# Altium schematic sheet USB3_Power.SchDoc
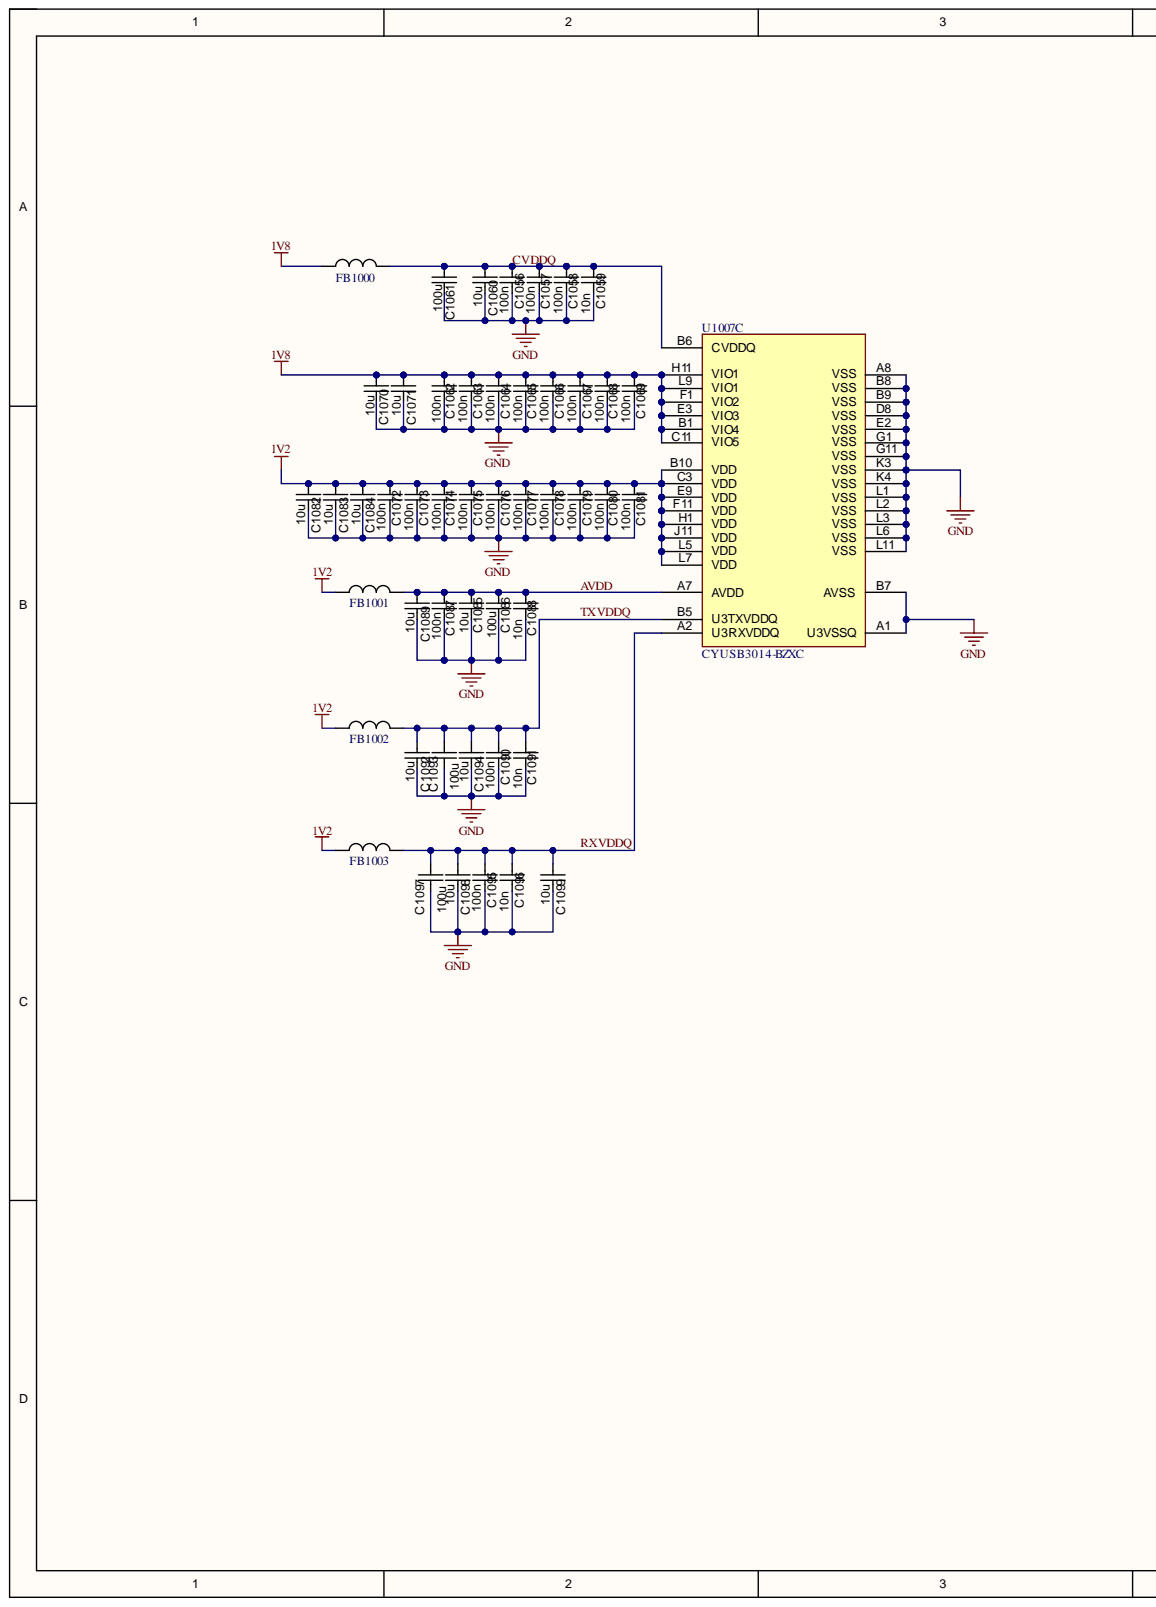
[diagram p0: USB3_Power.SchDoc, left]
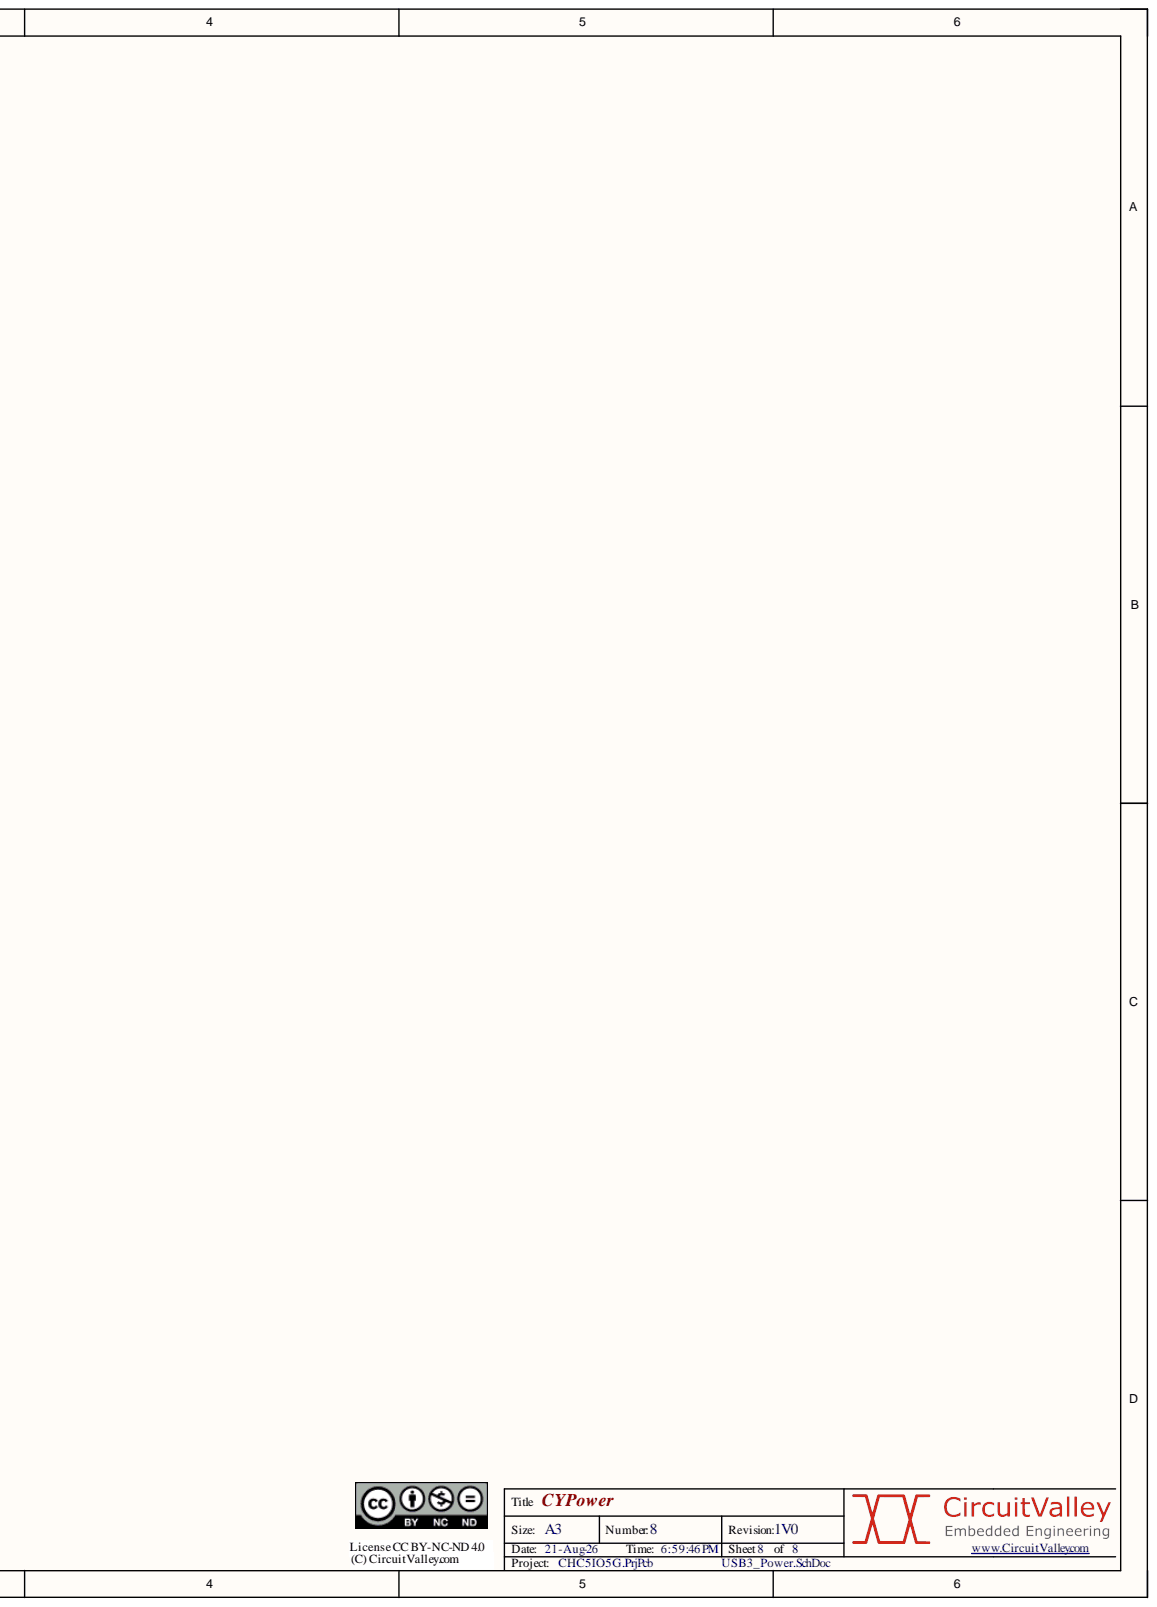
[diagram p1: USB3_Power.SchDoc, right]

## Parts
C1056  100n  [CAPC1005N]
C1057  100n  [CAPC1005N]
C1058  100n  [CAPC1005N]
C1059  10n  [CAPC1005N]
C1060  value 10u  [CAPC1608N]  (hidden comment: approved sub OK)
C1061  value 100u  [CAPC2012N]  (hidden comment: approved sub OK)
C1062  100n  [CAPC1005N]
C1063  100n  [CAPC1005N]
C1064  100n  [CAPC1005N]
C1065  100n  [CAPC1005N]
C1066  100n  [CAPC1005N]
C1067  100n  [CAPC1005N]
C1068  100n  [CAPC1005N]
C1069  100n  [CAPC1005N]
C1070  value 10u  [CAPC1608N]  (hidden comment: approved sub OK)
C1071  value 10u  [CAPC1608N]  (hidden comment: approved sub OK)
C1072  100n  [CAPC1005N]
C1073  100n  [CAPC1005N]
C1074  100n  [CAPC1005N]
C1075  100n  [CAPC1005N]
C1076  100n  [CAPC1005N]
C1077  100n  [CAPC1005N]
C1078  100n  [CAPC1005N]
C1079  100n  [CAPC1005N]
C1080  100n  [CAPC1005N]
C1081  100n  [CAPC1005N]
C1082  value 10u  [CAPC1608N]  (hidden comment: approved sub OK)
C1083  value 10u  [CAPC1608N]  (hidden comment: approved sub OK)
C1084  value 10u  [CAPC1608N]  (hidden comment: approved sub OK)
C1085  value 10u  [CAPC1608N]  (hidden comment: approved sub OK)
C1086  value 100u  [CAPC2012N]  (hidden comment: approved sub OK)
C1087  100n  [CAPC1005N]
C1088  10n  [CAPC1005N]
C1089  value 10u  [CAPC1608N]  (hidden comment: approved sub OK)
C1090  100n  [CAPC1005N]
C1091  10n  [CAPC1005N]
C1092  value 10u  [CAPC1608N]  (hidden comment: approved sub OK)
C1093  value 100u  [CAPC2012N]  (hidden comment: approved sub OK)
C1094  value 10u  [CAPC1608N]  (hidden comment: approved sub OK)
C1095  100n  [CAPC1005N]
C1096  10n  [CAPC1005N]
C1097  value 100u  [CAPC2012N]  (hidden comment: approved sub OK)
C1098  value 10u  [CAPC1608N]  (hidden comment: approved sub OK)
C1099  value 10u  [CAPC1608N]  (hidden comment: approved sub OK)
FB1000  Ferrite  [INDC1608N]  (value not drawn)
FB1001  Ferrite  [INDC1608N]  (value not drawn)
FB1002  Ferrite  [INDC1608N]  (value not drawn)
FB1003  Ferrite  [INDC1608N]  (value not drawn)
U1007  CYUSB3014-BZXC  [CYP-BZX121]  (part C)

## Nets
1V2: C1072.2 C1073.2 C1074.2 C1075.2 C1076.2 C1077.2 C1078.2 C1079.2 C1080.2 C1081.2 C1082.1 C1083.1 C1084.1 FB1001.1 FB1002.1 FB1003.1 U1007.B10(VDD) U1007.C3(VDD) U1007.E9(VDD) U1007.F11(VDD) U1007.H1(VDD) U1007.J11(VDD) U1007.L5(VDD) U1007.L7(VDD)
1V8: C1062.2 C1063.2 C1064.2 C1065.2 C1066.2 C1067.2 C1068.2 C1069.2 C1070.1 C1071.1 FB1000.1 U1007.B1(VIO4) U1007.C11(VIO5) U1007.E3(VIO3) U1007.F1(VIO2) U1007.H11(VIO1) U1007.L9(VIO1)
GND: C1056.1 C1057.1 C1058.1 C1059.1 C1060.2 C1061.2 C1062.1 C1063.1 C1064.1 C1065.1 C1066.1 C1067.1 C1068.1 C1069.1 C1070.2 C1071.2 C1072.1 C1073.1 C1074.1 C1075.1 C1076.1 C1077.1 C1078.1 C1079.1 C1080.1 C1081.1 C1082.2 C1083.2 C1084.2 C1085.2 C1086.2 C1087.1 C1088.1 C1089.2 C1090.1 C1091.1 C1092.2 C1093.2 C1094.2 C1095.1 C1096.1 C1097.2 C1098.2 C1099.2 U1007.A1(U3VSSQ) U1007.A8(VSS) U1007.B7(AVSS) U1007.B8(VSS) U1007.B9(VSS) U1007.D8(VSS) U1007.E2(VSS) U1007.G1(VSS) U1007.G11(VSS) U1007.K3(VSS) U1007.K4(VSS) U1007.L1(VSS) U1007.L2(VSS) U1007.L3(VSS) U1007.L6(VSS) U1007.L11(VSS)
AVDD: C1085.1 C1086.1 C1087.2 C1088.2 C1089.1 FB1001.2 U1007.A7(AVDD)
CVDDQ: C1056.2 C1057.2 C1058.2 C1059.2 C1060.1 C1061.1 FB1000.2 U1007.B6(CVDDQ)
RXVDDQ: C1095.2 C1096.2 C1097.1 C1098.1 C1099.1 FB1003.2 U1007.A2(U3RXVDDQ)
TXVDDQ: C1090.2 C1091.2 C1092.1 C1093.1 C1094.1 FB1002.2 U1007.B5(U3TXVDDQ)

## Library data of the parts
C1056-C1059 C1062-C1069 C1072-C1081 C1087 C1088 C1090 C1091 C1095 C1096 = CAPC_10n_0402_16V_10%: Capacitor SMD Cer 10nF 10% 16V X7R 0402; Manufacturer 1: AVX Corporation; Manufacturer Part Number 1: 0402YC103KAT2A; Ratings: 16V; X7R; Component Kind: Standard; ComponentLink1Description: http://www.avx.com/docs/Catalogs/cx7r.pdf; ComponentLink1URL: http://www.avx.com/docs/Catalogs/cx7r.pdf; ComponentLink2Description: http://www.yageo.com/documents/recent/UPY-GPHC_X7R_6.3V-to-50V_8.pdf; ComponentLink2URL: http://www.yageo.com/documents/recent/UPY-GPHC_X7R_6.3V-to-50V_8.pdf; Library Name: PBL - Capacitors.SCHLIB; Manufacturer 2: Yageo; Manufacturer Part Number 2: CC0402KRX7R7BB103; Operating Temperature: -55°C ~ 125°C; Package / Case: 0402 (1005 Metric); Size / Dimension: 0.039" L x 0.020" W (1.00mm x 0.50mm); Supplier 1: Digi-Key; Supplier 2: Digi-Key; Supplier Part Number 1: 478-1114-1-ND; Supplier Part Number 2: 311-1042-1-ND; Tolerance: ±10%
  C1056-C1058 C1062-C1069 C1072-C1081 C1087 C1090 C1095: Value: 100n
  C1059 C1088 C1091 C1096: Value: 10n
C1060 C1070 C1071 C1082-C1085 C1089 C1092 C1094 C1098 C1099 = CAPC_560p_0603_5%: Capacitor SMD Cer 560pF 5% 50V NP0 0603; Manufacturer 1: AVX Corporation; Manufacturer Part Number 1: 06035A561JAT2A; Capacitance: 470pF; Material: ceramic; Ratings: 50V; NP0; Applications: General Purpose; Component Kind: Standard; ComponentLink1Description: http://www.avx.com/docs/Catalogs/ccog.pdf; ComponentLink1URL: http://www.avx.com/docs/Catalogs/ccog.pdf; Height - Seated (Max): -; Lead Spacing: -; Lead Style: -; Library Name: PBL - Capacitors.SCHLIB; Mounting Type: Surface Mount, MLCC; Operating Temperature: -55°C ~ 125°C; Package / Case: 0603 (1608 metric); Size / Dimension: 0.063" L x 0.032" W (1.60mm x 0.81mm); Supplier 1: Digi-Key; Supplier Part Number 1: 478-1184-1-ND; Thickness (Max): 0.035" (0.90mm); Voltage - Rated: 50V
C1061 C1086 C1093 C1097 = CAPC_1u_0805: Capacitor SMD Cer 1uF 10% 16V X7R 0805; Manufacturer 1: TDK Corporation; Manufacturer Part Number 1: C2012X7R1C105K/1.25; Material: ceramic; Ratings: 16V; X7R; Component Kind: Standard; Library Name: PBL - Capacitors.SCHLIB; Package / Case: 0805 (2012 metric); Supplier 1: Digi-Key; Supplier Part Number 1: 445-1358-1-ND; Tolerance: ±10%
U1007 = CYUSB3014-BZXC: EZ-USB(R) FX3: SuperSpeed USB Controller, 512 KB, 60 I/Os, 0 to 70 degC, 121-Pin FBGA (BZX121); Manufacturer: Cypress; CPU Core: ARM926 EJ-S; Max. Operating Voltage (V): 1.25; Memory Architecture: SRAM; Memory Size (KB): 512; Min. Operating Voltage (V): 1.15; No. of I/Os: 60; Code_JEDEC: PUB 95; Component Kind: Standard; ComponentLink1Description: Manufacturer URL; ComponentLink1URL: http://www.cypress.com/; ComponentLink2Description: Datasheet; ComponentLink2URL: http://www.cypress.com/?docID=49087; ComponentLink3Description: Package Specification; ComponentLink3URL: http://www.cypress.com/?docID=45795; DatasheetVersion: Rev. *O; Library Name: Cypress USB Controllers.SchLib; Max. Operating Temp. (degreesC): 70; Min. Operating Temp. (degreesC): 0; Mounting Technology: Surface Mount; PackageDescription: 121-Ball FBGA, Body 10 x 10 mm, Pitch 0.8 mm; PackageReference: BZX121; PackageVersion: Rev. *D; PartNumber: CYUSB3014-BZXC; RoHS: Pb-Free; Supplier 1: Digi-Key; Supplier 2: Farnell; Supplier 3: Newark; Supplier 4: Mouser; Supplier Part Number 1: 428-3182-ND; Supplier Part Number 2: 2355789; Supplier Part Number 3: 54W7901; Supplier Part Number 4: 727-CYUSB3014-BZXC
FB1000-FB1003 = Ferrite: Component Kind: Standard; Library Name: cvlib.SchLib
